# Altium schematic sheet Sheet1.SchDoc(Sheet1)
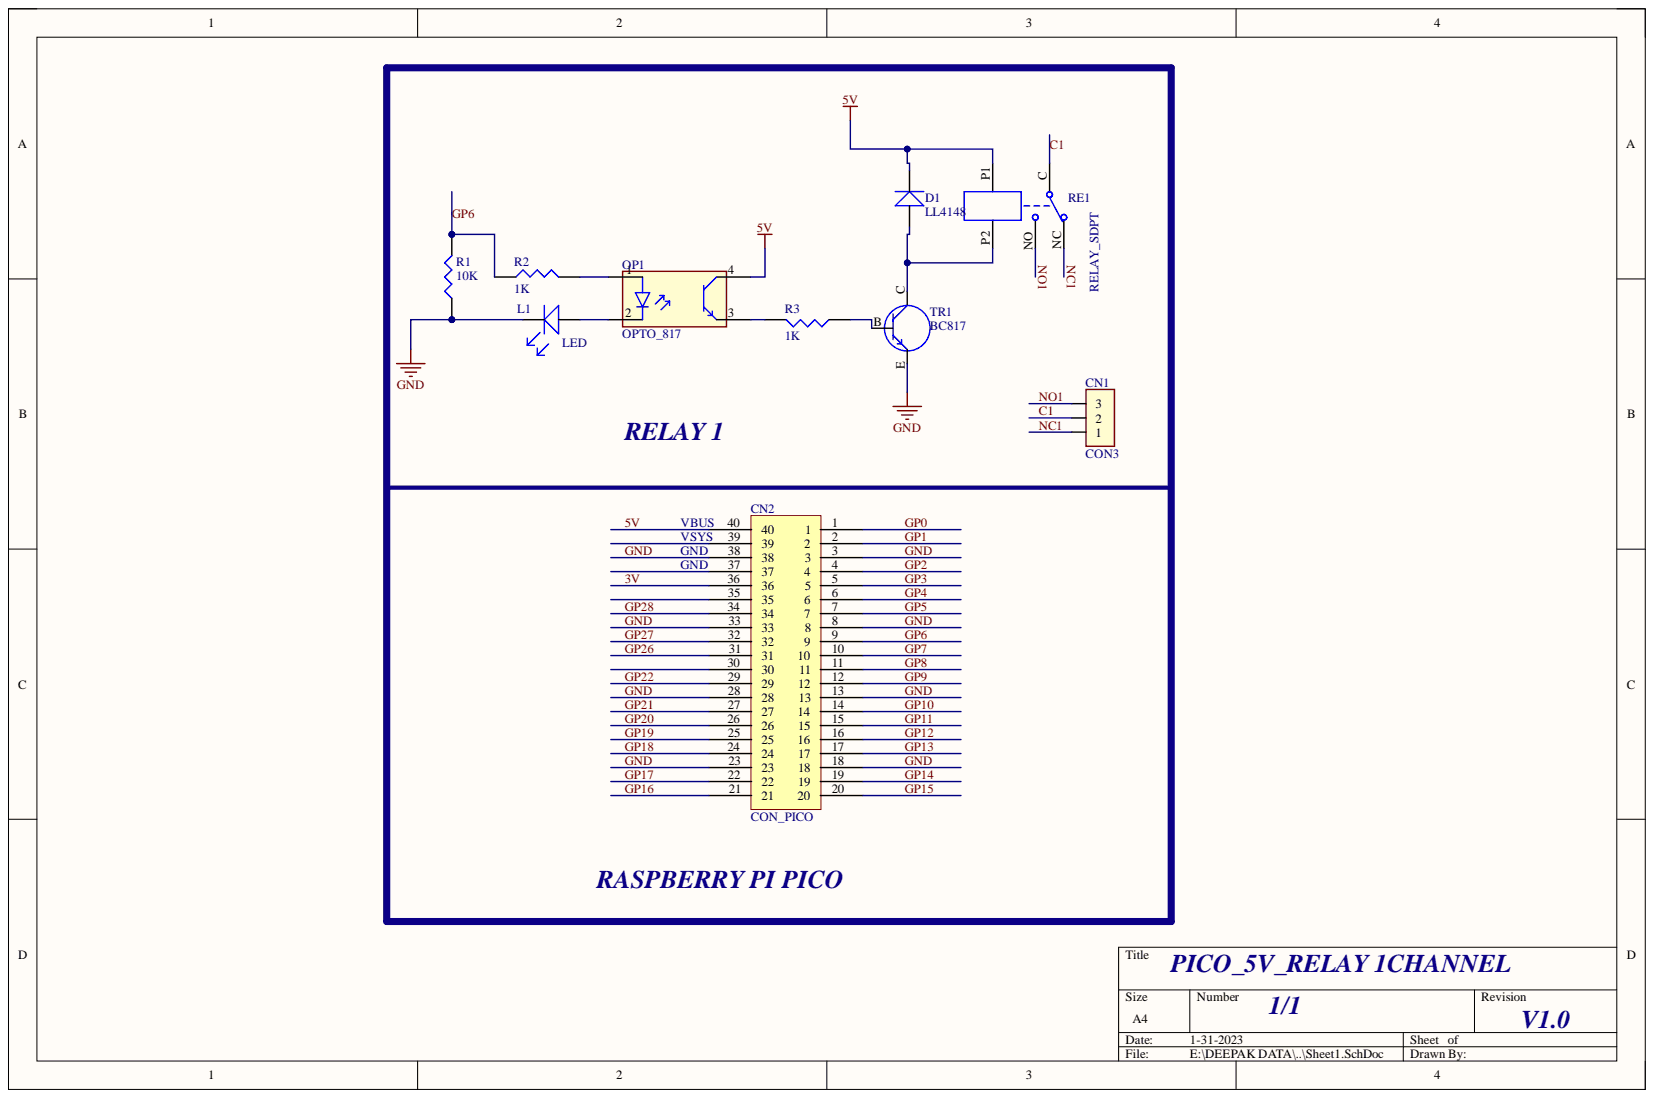
[diagram p0: Sheet1.SchDoc]

## Parts
CN1  CON3  [CON3_5.0_R2]
CN2  CON_PICO  [CON40_PICO_TOP BERG]
D1  LL4148  [MINI_MELF_SOD80]
L1  LED  [LED_0603_neg]
OP1  OPTO_817  [OPTO_817_SMD]
R1  10K  [0603]
R2  1K  [0603]
R3  1K  [0603]
RE1  RELAY_SDPT  [RELAY_SDPT]
TR1  BC817  [547_SOT23]

## Nets
3V: CN2.36
5V: CN2.40 D1.K OP1.4 RE1.P1
C1: CN1.2 RE1.C
GND: CN2.3 CN2.8 CN2.13 CN2.18 CN2.23 CN2.28 CN2.33 CN2.38 L1.K R1.1 TR1.E
GP0: CN2.1
GP1: CN2.2
GP2: CN2.4
GP3: CN2.5
GP4: CN2.6
GP5: CN2.7
GP6: CN2.9 R1.2 R2.1
GP7: CN2.10
GP8: CN2.11
GP9: CN2.12
GP10: CN2.14
GP11: CN2.15
GP12: CN2.16
GP13: CN2.17
GP14: CN2.19
GP15: CN2.20
GP16: CN2.21
GP17: CN2.22
GP18: CN2.24
GP19: CN2.25
GP20: CN2.26
GP21: CN2.27
GP22: CN2.29
GP26: CN2.31
GP27: CN2.32
GP28: CN2.34
NC1: CN1.1 RE1.NC
NO1: CN1.3 RE1.NO
NetD1_A: D1.A RE1.P2 TR1.C
NetL1_A: L1.A OP1.2
NetOP1_1: OP1.1 R2.2
NetOP1_3: OP1.3 R3.2
NetR3_1: R3.1 TR1.B

Not connected: CN2 30 35 37 39

## Library data of the parts
CN2 = CON40_PICO TOP MALE BERG: Component Kind: Standard; Library Name: dxp_pasl_lib.IntLib
D1 = DIODE: Component Kind: Standard; Library Name: dxp_pasl_lib.IntLib
R1-R3 = RES: Component Kind: Standard; Library Name: dxp_pasl_lib.IntLib
TR1 = TRANSISTER_NPN: Component Kind: Standard; Library Name: dxp_pasl_lib.IntLib
CN1 = CON3: Component Kind: Standard; Library Name: dxp_pasl_lib.IntLib
L1 = LED: Component Kind: Standard; Library Name: dxp_pasl_lib.IntLib
OP1 = OPTO_817: Component Kind: Standard; Library Name: dxp_pasl_lib.IntLib
RE1 = RELAY_SDPT: Component Kind: Standard; Library Name: dxp_pasl_lib.IntLib
